# Altium schematic sheet LoRa_Elemon_2.SchDoc(LoRa_Elemon_2)
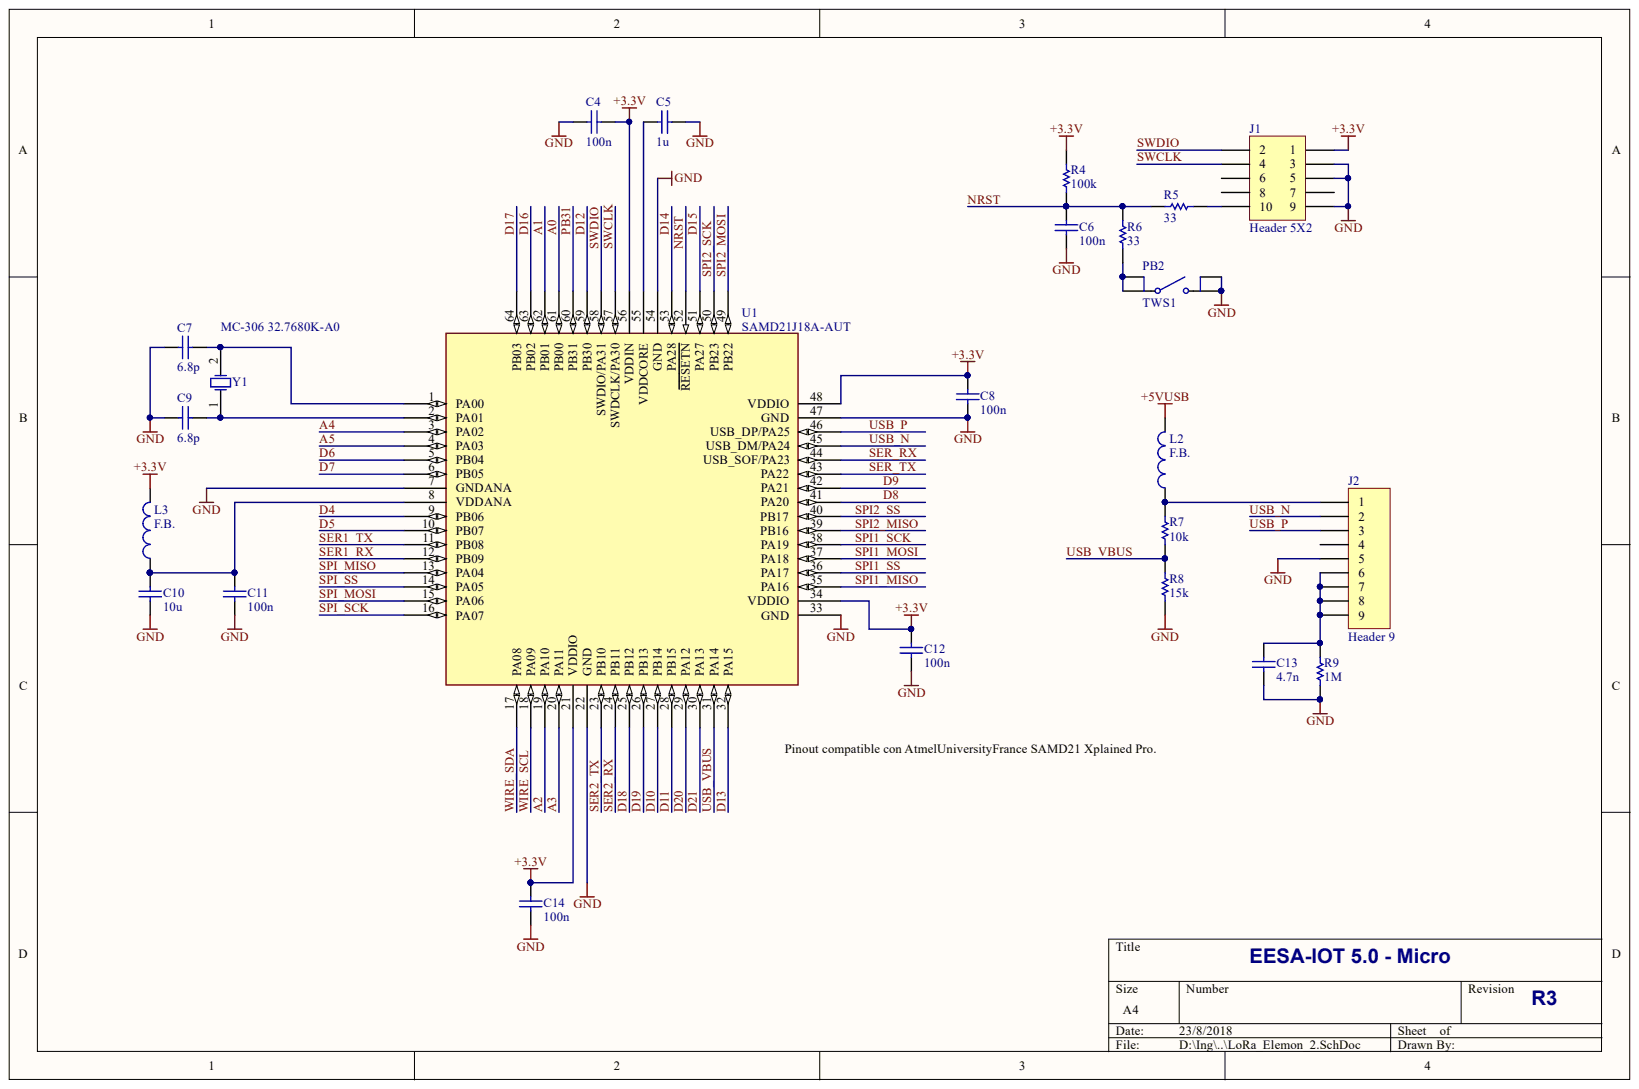
[diagram p0: LoRa_Elemon_2.SchDoc]

## Parts
C4  100n  [0805]
C5  1u  [0805]
C6  100n  [0805]
C7  6.8p  [0805]
C8  100n  [0805]
C9  6.8p  [0805]
C10  10u  [0805]
C11  100n  [0805]
C12  100n  [0805]
C13  4.7n  [0805]
C14  100n  [0805]
J1  Header 5X2  [HDR2X5P50]
J2  Header 9  [C8351-05BFDXNBFR]
L2  F.B.  [0805]
L3  F.B.  [0805]
PB2  TWS1  [TACWS1]
R4  100k  [0805]
R5  33  [0805]
R6  33  [0805]
R7  10k  [0805]
R8  15k  [0805]
R9  1M  [0805]
U1  value SAMD21J18A-AUT  MPN: SAMD21J18A-AUT  [AP6-00173]  (hidden comment: TQFP64)
Y1  MC-306 32.7680K-A0  [XTAL-MC-306]

## Nets
+3.3V: C4.2 C8.1 C12.2 C14.2 J1.1 L3.1 R4.2 U1.21(VDDIO) U1.34(VDDIO) U1.48(VDDIO) U1.56(VDDIN)
+5VUSB: L2.1
GND: C4.1 C5.2 C6.2 C7.1 C8.2 C9.1 C10.1 C11.1 C12.1 C13.1 C14.1 J1.3 J1.5 J1.9 J2.5 PB2.2 PB2.4 R8.1 R9.1 U1.7(GNDANA) U1.22(GND) U1.33(GND) U1.47(GND) U1.54(GND)
A0: U1.61(PB00)
A1: U1.62(PB01)
A2: U1.19(PA10)
A3: U1.20(PA11)
A4: U1.3(PA02)
A5: U1.4(PA03)
D4: U1.9(PB06)
D5: U1.10(PB07)
D6: U1.5(PB04)
D7: U1.6(PB05)
D8: U1.41(PA20)
D9: U1.42(PA21)
D10: U1.27(PB14)
D11: U1.28(PB15)
D12: U1.59(PB30)
D13: U1.32(PA15)
D14: U1.53(PA28)
D15: U1.51(PA27)
D16: U1.63(PB02)
D17: U1.64(PB03)
D18: U1.25(PB12)
D19: U1.26(PB13)
D20: U1.29(PA12)
D21: U1.30(PA13)
NRST: C6.1 R4.1 R5.1 R6.2 U1.52(RESETN)
PB31: U1.60(PB31)
SER1_RX: U1.12(PB09)
SER1_TX: U1.11(PB08)
SER2_RX: U1.24(PB11)
SER2_TX: U1.23(PB10)
SER_RX: U1.44(USB_SOF/PA23)
SER_TX: U1.43(PA22)
SPI1_MISO: U1.35(PA16)
SPI1_MOSI: U1.37(PA18)
SPI1_SCK: U1.38(PA19)
SPI1_SS: U1.36(PA17)
SPI2_MISO: U1.39(PB16)
SPI2_MOSI: U1.49(PB22)
SPI2_SCK: U1.50(PB23)
SPI2_SS: U1.40(PB17)
SPI_MISO: U1.13(PA04)
SPI_MOSI: U1.15(PA06)
SPI_SCK: U1.16(PA07)
SPI_SS: U1.14(PA05)
SWCLK: J1.4 U1.57(SWDCLK/PA30)
SWDIO: J1.2 U1.58(SWDIO/PA31)
USB_N: J2.2 U1.45(USB_DM/PA24)
USB_P: J2.3 U1.46(USB_DP/PA25)
USB_VBUS: R7.1 R8.2 U1.31(PA14)
WIRE_SCL: U1.18(PA09)
WIRE_SDA: U1.17(PA08)
NetC5_1: C5.1 U1.55(VDDCORE)
NetC7_2: C7.2 U1.1(PA00) Y1.2
NetC9_2: C9.2 U1.2(PA01) Y1.1
NetC10_2: C10.2 C11.2 L3.2 U1.8(VDDANA)
NetC13_2: C13.2 J2.6 J2.7 J2.8 J2.9 R9.2
NetJ1_10: J1.10 R5.2
NetJ2_1: J2.1 L2.2 R7.2
NetPB2_1: PB2.1 PB2.3 R6.1

Not connected: J1 6 7 8; J2 4

## Library data of the parts
C4-C14 = Cap: Capacitor; Value: 10nF; Component Kind: Standard; Library Name: Miscellaneous Devices.IntLib; Published: 8-Jun-2000; Revision: July-2002: Re-released for DXP Platform.; Signal Integrity: Cap; Simulation: CAP; Publisher: Altium Limited
J1 = Header 5X2: Header, 5-Pin, Dual row; Component Kind: Standard; LatestRevisionDate: 17-Jul-2002; LatestRevisionNote: Re-released for DXP Platform.; Library Name: Miscellaneous Connectors.IntLib; Signal Integrity: Connector; Publisher: Altium Limited
J2 = Header 9: Header, 9-Pin; Component Kind: Standard; LatestRevisionDate: 17-Jul-2002; LatestRevisionNote: Re-released for DXP Platform.; Library Name: Miscellaneous Connectors.IntLib; Signal Integrity: Connector; Publisher: Altium Limited
L2 L3 = Inductor: Code_IEC: 1005; Value: 10mH; Code_JEITA: 0402; Component Kind: Standard; Description: Inductor; LatestRevisionDate: 29-May-2009; LatestRevisionNote: IPC-7351 Footprint Added.; Library Name: Miscellaneous Devices.IntLib; PackageDescription: Chip Inductor; PackageReference: 0402-A; Published: 8-Jun-2000; Signal Integrity: Inductor; Simulation: INDUCTOR; Publisher: Altium Limited
R4-R9 = Res1: Resistor; Value: 1K; Component Kind: Standard; LatestRevisionDate: 17-Jul-2002; LatestRevisionNote: Re-released for DXP Platform.; Library Name: Miscellaneous Devices.IntLib; PackageDescription: Axial Device, Thru-Hole; 2 Leads; 0.3 in Pin Spacing; PackageReference: AXIAL-0.3; Published: 8-Jun-2000; Signal Integrity: Res; Simulation: RESISTOR; Publisher: Altium Limited
U1 = A06-1041: Atmel 32-bit RISC MCU 64pin; PartGroup: Integrated Circuits,MCU, MPU, DSP,ARM MCU; Database Table Name: AltiumComponents; TemperatureRange: -40°C to +85°C; AN: A06-1041; Component Kind: Standard; Height: 1.20000004768372; Library Name: AltiumDbLib.DbLib; Manufacturer: ATMEL
Y1 = XTAL: Crystal Oscillator SMD 32kHz; Component Kind: Standard; LatestRevisionDate: 17-Jul-2002; LatestRevisionNote: Re-released for DXP Platform.; Library Name: Miscellaneous Devices.IntLib; PackageDescription: Crystal, Thru-Hole; 2 Leads; Body 3.1 x 8.2 mm (Dia.xH); PackageReference: R38; Published: 8-Jun-2000; Simulation: XTAL; Publisher: Altium Limited
PB2 = TWS1: Component Kind: Standard; Library Name: LoRa_Elemon.SchLib
